# Altium schematic sheet ice_cool_pee_controller.SchDoc
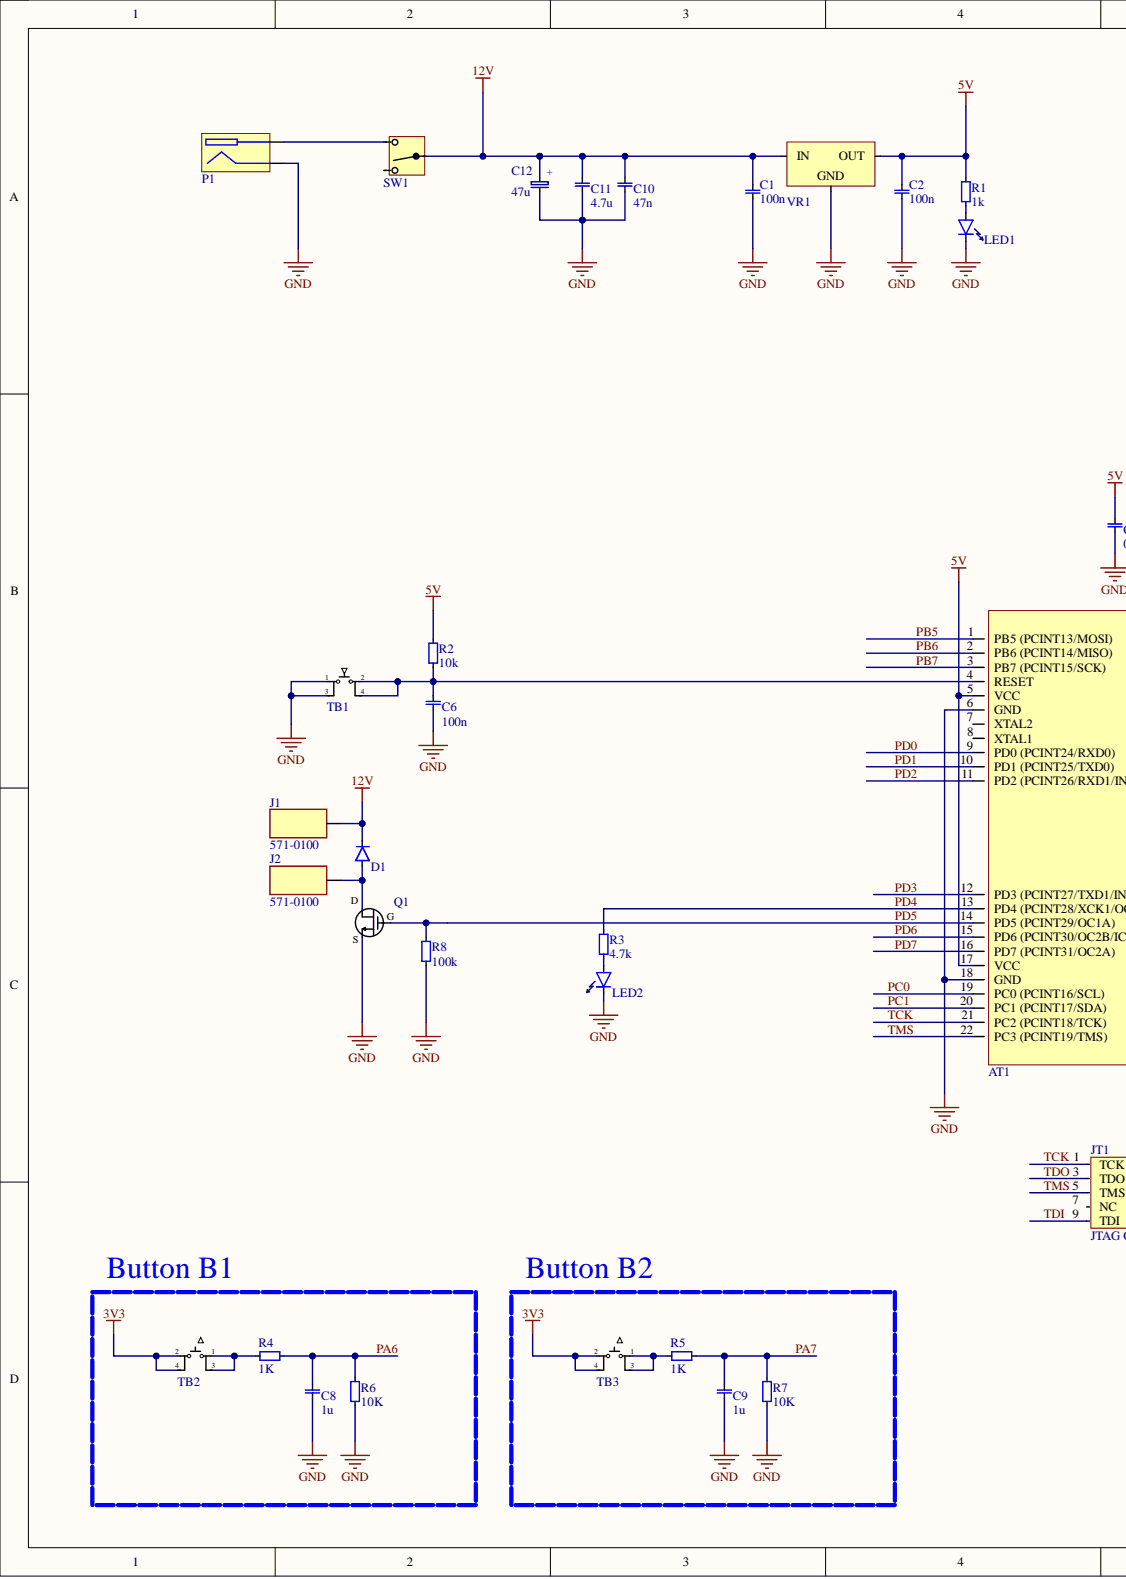
[diagram p0: ice_cool_pee_controller.SchDoc, left]
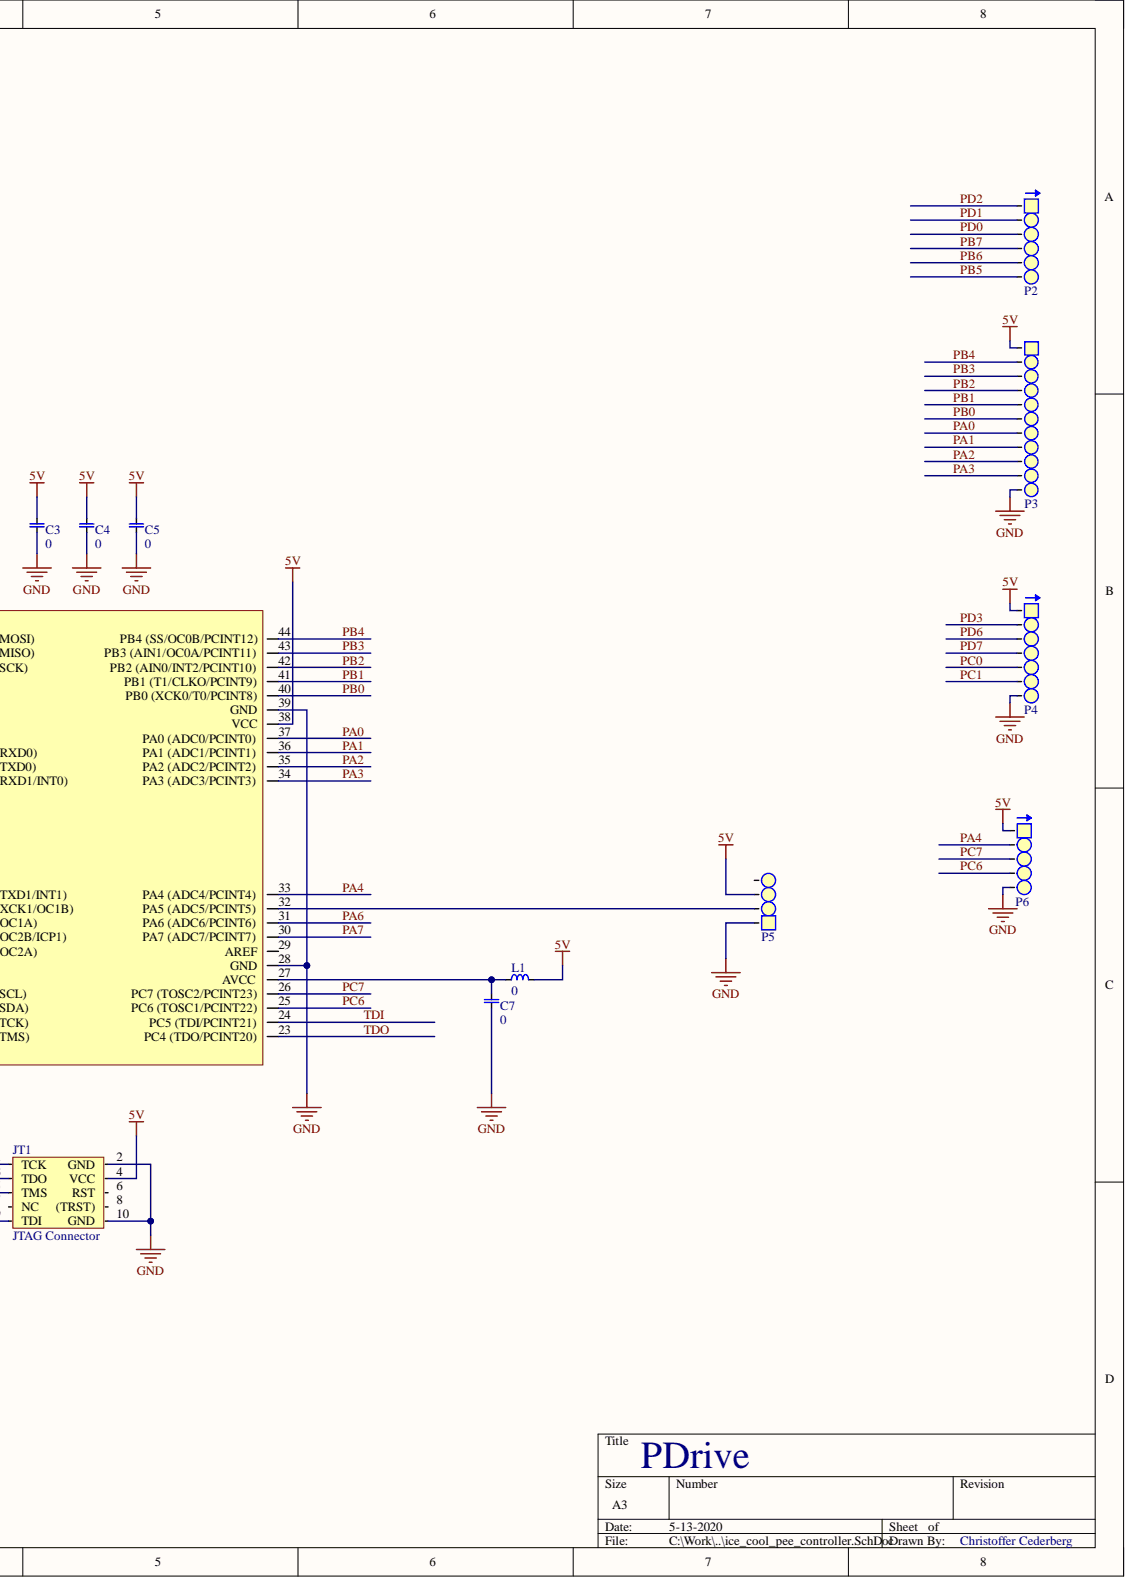
[diagram p1: ice_cool_pee_controller.SchDoc, right]

## Parts
AT1  ATmega644PA  [TQFP-44]  (value not drawn)
C1  value 100n  [0603 cap]  (hidden comment: 0603, capacitor)
C2  value 100n  [0603 cap]  (hidden comment: 0603, capacitor)
C3  value 0  [0603 cap]  (hidden comment: 0603, capacitor)
C4  value 0  [0603 cap]  (hidden comment: 0603, capacitor)
C5  value 0  [0603 cap]  (hidden comment: 0603, capacitor)
C6  value 100n  [0603 cap]  (hidden comment: 0603, capacitor)
C7  value 0  [0603 cap]  (hidden comment: 0603, capacitor)
C8  value 1u  [0603 cap]  (hidden comment: 0603, capacitor)
C9  value 1u  [0603 cap]  (hidden comment: 0603, capacitor)
C10  value 47n  [1206, capasitor]  (hidden comment: 1206, capacitor)
C11  value 4.7u  [1206, capasitor]  (hidden comment: 1206, capacitor)
C12  value 47u  [CASE_X]  (hidden comment: Cap, case X)
D1  Diode, TH  [TO-220-F2]  (value not drawn)
J1  571-0100  [Banana Conn VCC]
J2  571-0100  [Banana Conn GND]
JT1  JTAG Connector  [Atmel_JTAG]
L1  value 0  [1812, coil]  (hidden comment: Coil)
LED1  0805, LED  [0805_led]  (value not drawn)
LED2  0805, LED  [0805_led]  (value not drawn)
P1  Power socket  [DC_PLUG]  (value not drawn)
P2  1x6 Pin Header  [1x6 Pin Header]  (value not drawn)
P3  1x11 Pin Header  [1x11 Pin Header]  (value not drawn)
P4  1x7 Pin Header  [1x7 Pin Header]  (value not drawn)
P5  4x1 Pin Header  [padFour_L]  (value not drawn)
P6  1x5 Pin Header  [padFive_L]  (value not drawn)
Q1  IRF640  [TO-220]  (value not drawn)
R1  value 1k  [0603]  (hidden comment: 0603, resistor)
R2  value 10k  [0603]  (hidden comment: 0603, resistor)
R3  value 4.7k  [0603]  (hidden comment: 0603, resistor)
R4  value 1K  [0603]  (hidden comment: 0603, resistor)
R5  value 1K  [0603]  (hidden comment: 0603, resistor)
R6  value 10K  [0603]  (hidden comment: 0603, resistor)
R7  value 10K  [0603]  (hidden comment: 0603, resistor)
R8  value 100k  [0603]  (hidden comment: 0603, resistor)
SW1  Switch Horizontal  [Switch_Horizontal]  (value not drawn)
TB1  Tactile Button  [Button smd]  (value not drawn)
TB2  Tactile Button  [Button smd]  (value not drawn)
TB3  Tactile Button  [Button smd]  (value not drawn)
VR1  L7805  [TO-220]  (value not drawn)

## Nets
3V3: TB2.2 TB2.4 TB3.2 TB3.4
5V: AT1.5(VCC) AT1.17(VCC) AT1.38(VCC) C2.1 C3.1 C4.1 C5.1 JT1.4 L1.1 P3.1 P4.1 P5.3 P6.1 R1.0 R2.0 VR1.3
12V: C1.1 C10.1 C11.1 C12.2 D1.1 J1.1 SW1.2 VR1.1
GND: AT1.6(GND) AT1.18(GND) AT1.28(GND) AT1.39(GND) C1.0 C2.0 C3.0 C4.0 C5.0 C6.0 C7.0 C8.0 C9.0 C10.0 C11.0 C12.1 JT1.2 JT1.10 LED1.0 LED2.0 P1.2 P3.11 P4.7 P5.1 P6.5 Q1.3 R6.0 R7.0 R8.1 TB1.1 TB1.3 VR1.2
PA0: AT1.37(PA0_(ADC0/PCINT0)) P3.7
PA1: AT1.36(PA1_(ADC1/PCINT1)) P3.8
PA2: AT1.35(PA2_(ADC2/PCINT2)) P3.9
PA3: AT1.34(PA3_(ADC3/PCINT3)) P3.10
PA4: AT1.33(PA4_(ADC4/PCINT4)) P6.2
PA6: AT1.31(PA6_(ADC6/PCINT6)) C8.1 R4.1 R6.1
PA7: AT1.30(PA7_(ADC7/PCINT7)) C9.1 R5.1 R7.1
PB0: AT1.40(PB0_(XCK0/T0/PCINT8)) P3.6
PB1: AT1.41(PB1_(T1/CLKO/PCINT9)) P3.5
PB2: AT1.42(PB2_(AIN0/INT2/PCINT10)) P3.4
PB3: AT1.43(PB3_(AIN1/OC0A/PCINT11)) P3.3
PB4: AT1.44(PB4_(SS/OC0B/PCINT12)) P3.2
PB5: AT1.1(PB5_(PCINT13/MOSI)) P2.6
PB6: AT1.2(PB6_(PCINT14/MISO)) P2.5
PB7: AT1.3(PB7_(PCINT15/SCK)) P2.4
PC0: AT1.19(PC0_(PCINT16/SCL)) P4.5
PC1: AT1.20(PC1_(PCINT17/SDA)) P4.6
PC6: AT1.25(PC6_(TOSC1/PCINT22)) P6.4
PC7: AT1.26(PC7_(TOSC2/PCINT23)) P6.3
PD0: AT1.9(PD0_(PCINT24/RXD0)) P2.3
PD1: AT1.10(PD1_(PCINT25/TXD0)) P2.2
PD2: AT1.11(PD2_(PCINT26/RXD1/INT0)) P2.1
PD3: AT1.12(PD3_(PCINT27/TXD1/INT1)) P4.2
PD4: AT1.13(PD4_(PCINT28/XCK1/OC1B)) R3.0
PD5: AT1.14(PD5_(PCINT29/OC1A)) Q1.1 R8.0
PD6: AT1.15(PD6_(PCINT30/OC2B/ICP1)) P4.3
PD7: AT1.16(PD7_(PCINT31/OC2A)) P4.4
TCK: AT1.21(PC2_(PCINT18/TCK)) JT1.1
TDI: AT1.24(PC5_(TDI/PCINT21)) JT1.9
TDO: AT1.23(PC4_(TDO/PCINT20)) JT1.3
TMS: AT1.22(PC3_(PCINT19/TMS)) JT1.5
NetAT1_4: AT1.4(RESET) C6.1 R2.1 TB1.2 TB1.4
NetAT1_27: AT1.27(AVCC) C7.1 L1.2
NetAT1_32: AT1.32(PA5_(ADC5/PCINT5)) P5.2
NetD1_2: D1.2 J2.1 Q1.2
NetLED1_1: LED1.1 R1.1
NetLED2_1: LED2.1 R3.1
NetP1_1: P1.1 SW1.3
NetR4_0: R4.0 TB2.1 TB2.3
NetR5_0: R5.0 TB3.1 TB3.3

Not connected: AT1 7(XTAL2) 8(XTAL1) 29(AREF); JT1 6 7 8; P5 4; SW1 1

## Library data of the parts
AT1 = ATmega644PA: ATmega644PA - Small but big and PicoPower; Component Kind: Standard; Farnell: 2484915; Value: ATmega644PA; Library Name: jclib.IntLib
J1 J2 = Banana conn: Banana Connector 571-0100; Manufacturer_Name: Deltron; Component Kind: Standard; Datasheet Link: https://www.dem-uk.com/deltron-components/Data/Product_Downloads/deltron-571-0100-4mm-90deg-single-socket-data.pdf; Height: 12.4mm; Manufacturer_Part_Number: 571-0100; Mouser Part Number: 164-6218; Mouser Price/Stock: https://www.mouser.com/Search/Refine.aspx?Keyword=164-6218; Library Name: jclib.IntLib
JT1 = Atmel JTAG: Atmel JTAG Connector; Component Kind: Standard; Library Name: jclib.IntLib
LED1 LED2 = 0805, LED: Value: 0805 LED; Component Kind: Standard; Library Name: jclib.IntLib
P2 = 1x6 Pin Header: 2.54 mm; Value: 1x3 Pin Header; Component Kind: Standard; Library Name: jclib.IntLib
P3 = 1x11 Pin Header: 2.54 mm; Value: 1x8 Pin Header; Component Kind: Standard; Library Name: jclib.IntLib
P4 = 1x7 Pin Header: 2.54 mm; Value: 1x8 Pin Header; Component Kind: Standard; Library Name: jclib.IntLib
P5 = 1x4 Pin Header: 2.54 mm; Component Kind: Standard; Value: 4x1 Pin Header; Library Name: jclib.IntLib
P6 = 1x5 Pin Header: 2.54 mm; Component Kind: Standard; Value: 1x5 Pin Header; Library Name: jclib.IntLib
Q1 = IRF640: Value: N Channel MOSFET; Component Kind: Standard; Farnell: ETF! , 8648298; Library Name: jclib.IntLib
SW1 = Switch Horizontal: Value: Toggle Switch; Component Kind: Standard; Farnell: 2435204; Library Name: jclib.IntLib
TB1-TB3 = Button SMD: Tactile Switch Small Low; Component Kind: Standard; ELFA: 110-87-406; Farnell: 2320087; Value: Button SMD; Library Name: eitp15_lab1_lib.IntLib
C1-C9 = 0603, capacitor: Component Kind: Standard; Library Name: jclib.IntLib
C10 C11 = 1206, capacitor: Component Kind: Standard; Library Name: jclib.IntLib
C12 = Cap, case X: Component Kind: Standard; Library Name: jclib.IntLib
D1 = Diode, TH: Component Kind: Standard; Library Name: jclib.IntLib
L1 = Coil: Component Kind: Standard; Library Name: jclib.IntLib
P1 = Power socket: Component Kind: Standard; Library Name: jclib.IntLib
R1-R8 = 0603, resistor: Component Kind: Standard; Library Name: jclib.IntLib
VR1 = L7805: Component Kind: Standard; Value: L7805; Library Name: jclib.SchLib
